# Altium schematic sheet Power Supply.SchDoc
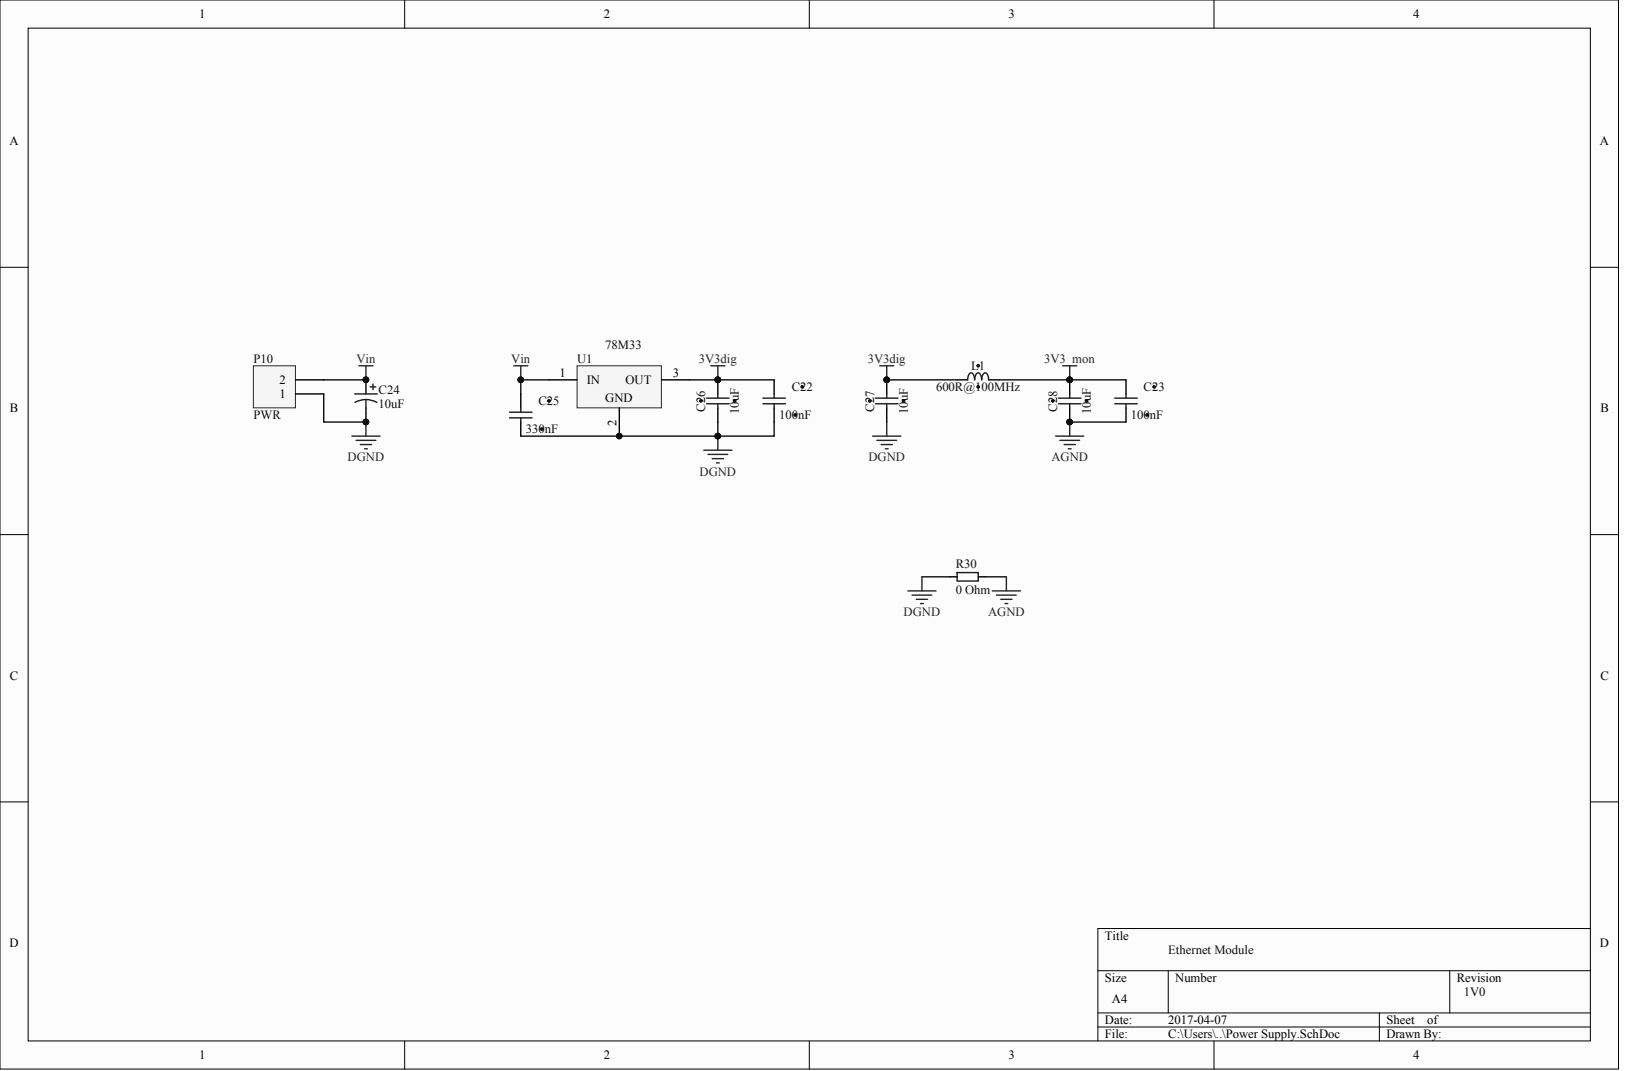
[diagram p0: Power Supply.SchDoc]

## Parts
C22  100nF  [CAPC1005X55N]
C23  100nF  [CAPC1005X55N]
C24  10uF
C25  330nF
C26  10uF  [CAPC1608X87N]
C27  10uF  [CAPC1608X87N]
C28  10uF  [CAPC1608X87N]
L1  600R@100MHz  [INDC1005X55N]
P10  PWR
R30  Resistance (Ohms): 0 Ohm  (hidden comment: ERJ-2RKF2201X)
U1  78M33

## Nets
3V3_MON: C23.2 C28.2 L1.1
3V3DIG: C22.2 C26.2 C27.2 L1.2 U1.3
AGND: C23.1 C28.1 R30.2
DGND: C22.1 C24.2 C25.1 C26.1 C27.1 P10.1 R30.1 U1.2
VIN: C24.1 C25.2 P10.2 U1.1

## Library data of the parts
C22 C23 = (no symbol name): Part Number: CC0402_100NF_16V_10%_X7R; Part Description: SMD Multilayer Chip Ceramic Capacitor; Manufacturer: GENERIC; Manufacturer1 Part Number: GRM155R71C104KA88D; Voltage: 16V; TC: X7R; Tolerance: ±10%; Table: Capacitors SMD; Component Kind: Standard; Component Type: Standard; Pin Count: 2; Value: 100nF; Manufacturer Part Number: CC0402_100NF_16V_10%_X7R; Case: 0402; Mounted: Yes; Socket: No; SMD: Yes; Manufacturer1 Example: MURATA; Manufacturer1 ComponentHeight: 0.55mm; HelpURL: \\cern.ch\dfs\Applications\Altium\Datasheets\CC0402_X7R_MURATA_GRM.pdf; CreateDate: 05/12/2013; LatestRevisionDate: 03/12/2007; DigiKey part no.: 490-3261-1-ND; Author: CERN DEM JLC
C24 = (no symbol name): Manufacturer part no.: T491C106K025AT; Manufacturer: GENERIC; Tolerance: +/-10%; Voltage: 25V; Type: Tantalum; LatestRevisionDate: 17-Jul-2002; Value: 10uF; DigiKey part no.: 399-3734-1-ND; Manufacturer example: KEMET
C25 = (no symbol name): Part Description: SMD Multilayer Chip Ceramic Capacitor; Manufacturer: GENERIC; Manufacturer1 Part Number: C1608X7R1E334K080AC; Voltage: 25V; TC: X7R; Tolerance: ±10%; Table: Capacitors SMD; Component Kind: Standard; Component Type: Standard; Pin Count: 2; Value: 330nF; Case: 0603; Mounted: Yes; SMD: Yes; Manufacturer1 Example: TDK Corporation; HelpURL: \\cern.ch\dfs\Applications\Altium\Datasheets\CC0402_X7R_MURATA_GRM.pdf; CreateDate: 05/12/2013; LatestRevisionDate: 03/12/2007; DigiKey part no.: 445-7416-1-ND; Author: CERN DEM JLC
C26-C28 = (no symbol name): Part Number: CC0603_10UF_6.3V_20%_X5R; Part Description: SMD Multilayer Chip Ceramic Capacitor; Manufacturer: GENERIC; Manufacturer Part Number: C0603C106M9PACTU; Voltage: 6.3V; TC: X5R; Tolerance: ±20%; Table: Capacitors SMD; Component Kind: Standard; Component Type: Standard; Pin Count: 2; Value: 10uF; Case: 0603; Mounted: Yes; Socket: No; SMD: Yes; Manufacturer1 Example: KEMET; Manufacturer1 ComponentHeight: 0.95mm; HelpURL: \\cern.ch\dfs\Applications\Altium\Datasheets\CC0603_X5R_KEMET.pdf; CreateDate: 03/12/2007; LatestRevisionDate: 03/12/2007; DigiKey part no.: 399-5504-1-ND; Author: CERN DEM JLC
L1 = (no symbol name): Part Number: IND0402_MURATA_BLM15HD601SN1; Part Description: SMD EMIFIL Suppression Chip Ferrite Bead; Manufacturer: MURATA; Manufacturer Part Number: BLM15HD601SN1D; Power: 300mA; Resistance: 0.85R; Tolerance: ±25%; Table: Inductors SMD; Component Kind: Standard; Component Type: Standard; Pin Count: 2; Value: 600R@100MHz; Case: 0402; Mounted: Yes; Socket: No; SMD: Yes; HelpURL: \\cern.ch\dfs\Applications\Altium\Datasheets\IND0402_MURATA_BLM15H.pdf; LatestRevisionDate: 2012-02-28; DigiKey part no.: 490-4002-1-ND; Author: CERN DEM JLC
R30 = (no symbol name): Manufacturer Part Number: RC0805JR-070RL; Manufacturer: GENERIC; Tolerance: ±1%; Power (Watts): 0.125W; Temperature Coefficient: ±100ppm/°C; Supplier 1: Digi-Key; Manufacturer example: Yageo; RoHS: RoHS Compliant; Category: Resistors; Pricing 1: 1=0.1, 50=0.015, 100=0.011, 250=0.0084, 500=0.00674, 1000=0.00496, 5000=0.00355 (USD); Packaging: Cut Tape (CT); Composition: Thick Film; Operating Temperature: -55°C ~ 155°C; Package / Case: 0805 (2012 Metric); Supplier Device Package: 0805; Size / Dimension: 2.00mm x 1.2mm; Number of Terminations: 2; DigiKey part no.: 311-0.0ARCT-ND
U1 = (no symbol name): Manufacturer part no.: UA78M33CKVURG3; Note: Pin 2 is electrically connected to TAB.; Published: 15-Apr-2002; DatasheetVersion: Jul-1999; PackageVersion: Sep-1995; PackageReference: KC03; PackageDescription: TO, Thru-Hole, Vertical, Heatsink Mounted; 3 In-Line Leads; Pitch 2.54 mm; ComponentLink1Description: Manufacturer Link; ComponentLink1URL: http://www.ti.com/; ComponentLink2Description: Datasheet; ComponentLink2URL: http://www-s.ti.com/sc/ds/ua78m05.pdf; DigiKey part no.: 296-21208-1-ND; Publisher: Altium Limited
P10 = (no symbol name): LatestRevisionDate: 17-Jul-2002; LatestRevisionNote: Re-released for DXP Platform.; DigiKey part no.: 277-1273-ND; Publisher: Altium Limited
